# Altium schematic sheet JacdacRgbLedGeneric 60.SchDoc
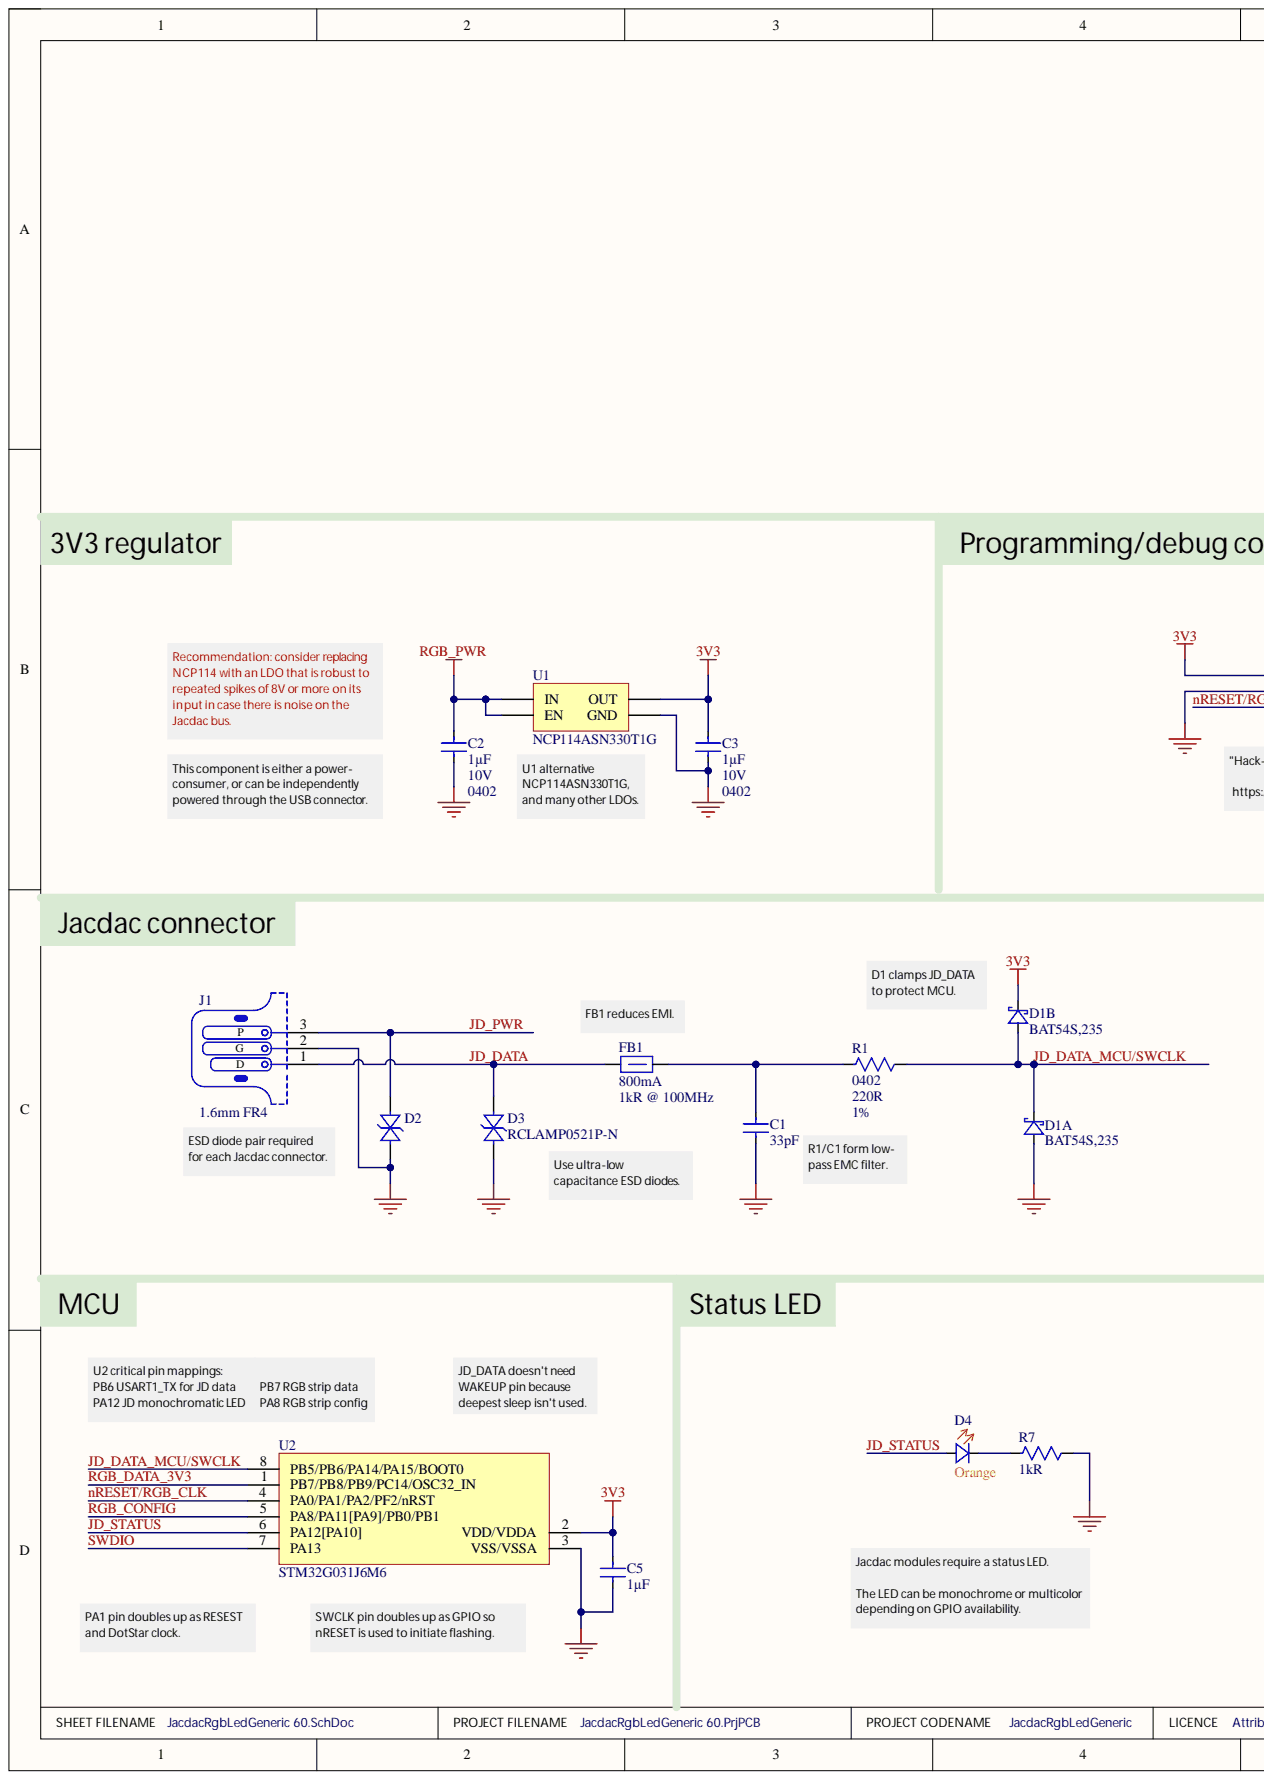
[diagram p0: JacdacRgbLedGeneric 60.SchDoc, left]
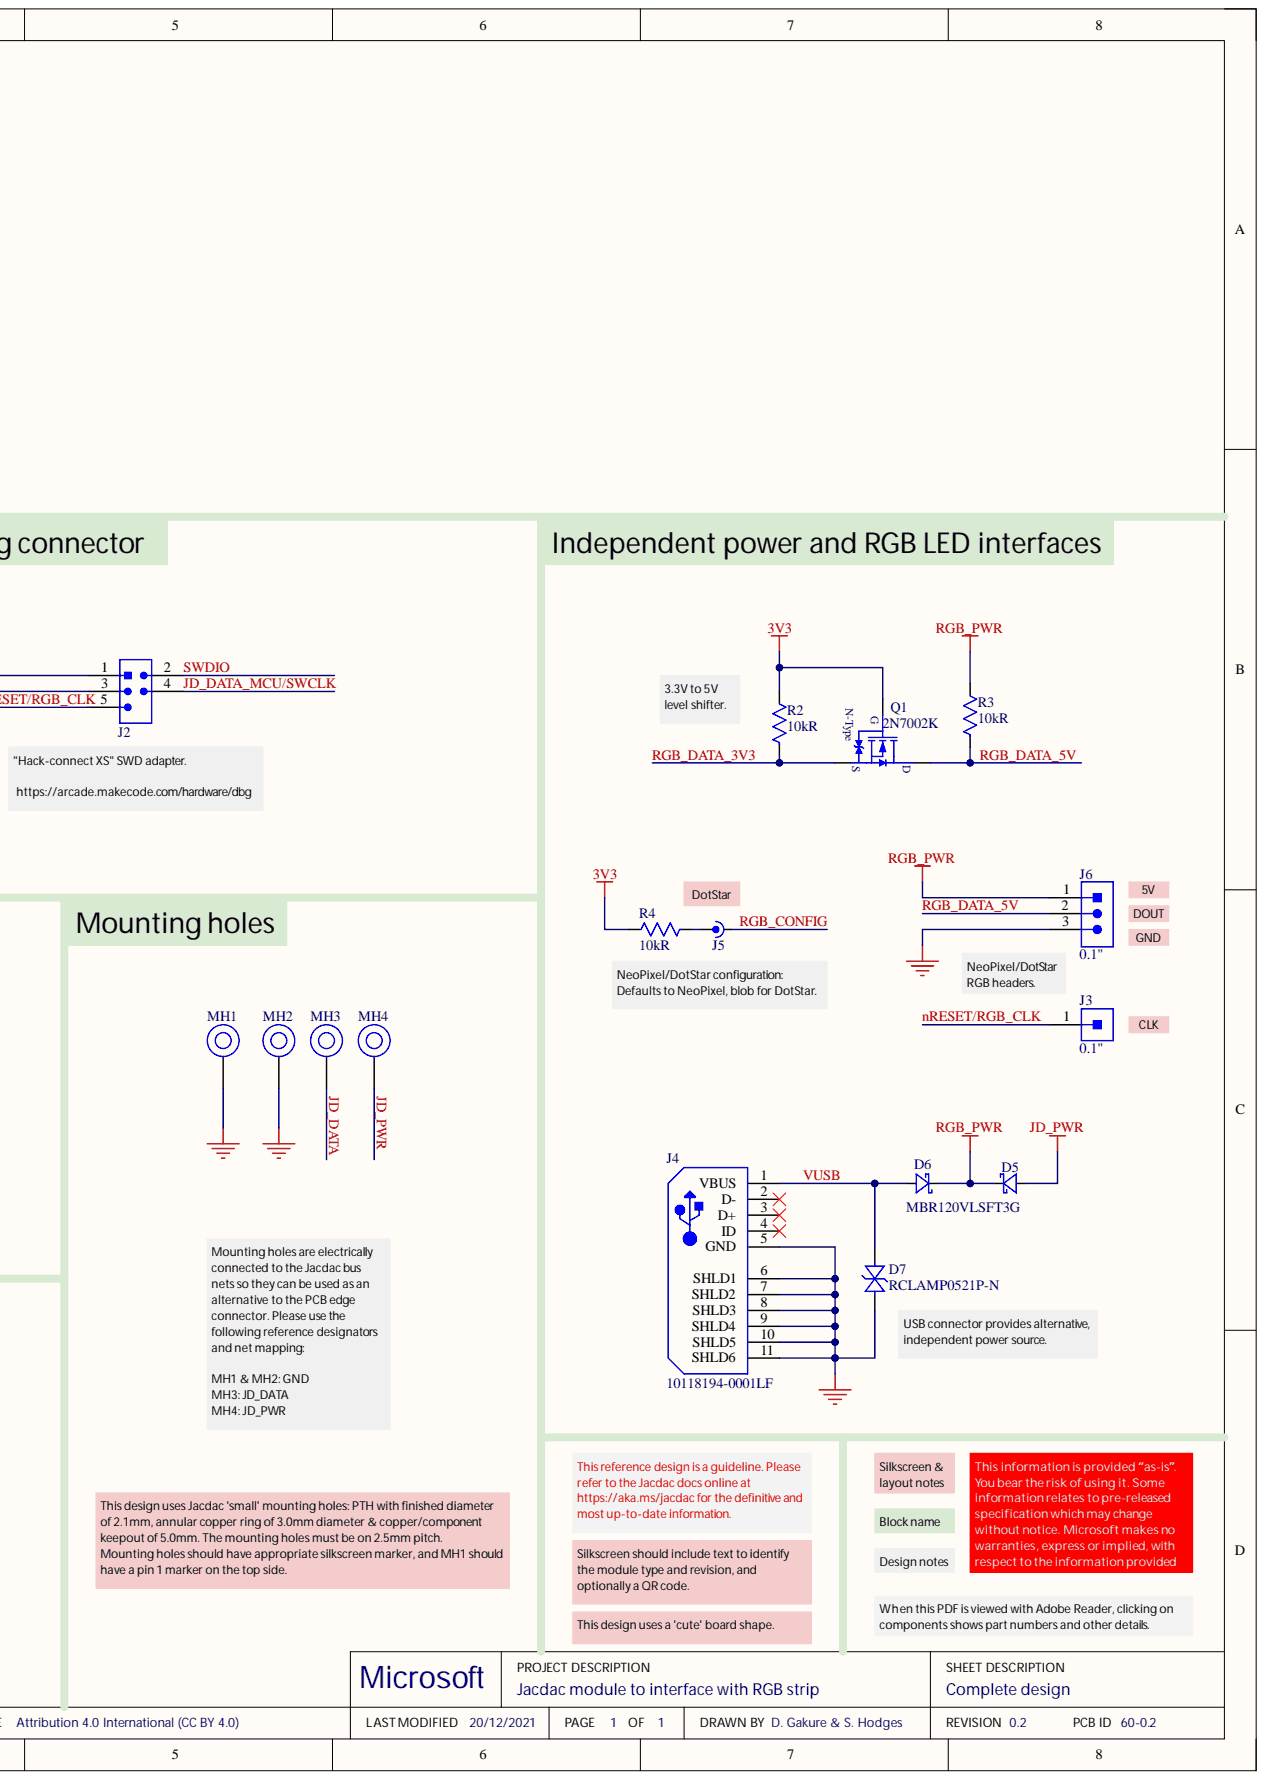
[diagram p1: JacdacRgbLedGeneric 60.SchDoc, right]

## Parts
C1  Capacitance: 33pF
C2  Capacitance: 1µF  Case Code (Imperial): 0402  Voltage Rating: 10V
C3  Capacitance: 1µF  Case Code (Imperial): 0402  Voltage Rating: 10V
C5  Capacitance: 1µF
D1  Manufacturer Part Number: BAT54S,235  (parts A,B)
D2  (no value)
D3  Manufacturer Part Number: RCLAMP0521P-N
D4  Colour: Orange
D5  Manufacturer Part Number: MBR120VLSFT3G
D6  Manufacturer Part Number: MBR120VLSFT3G
D7  Manufacturer Part Number: RCLAMP0521P-N
FB1  Current Rating: 800mA  Impedance: 1kR @ 100MHz
J1  PCB Type: 1.6mm FR4
J2  (no value)
J3  (no value)
J4  Manufacturer Part Number: 10118194-0001LF
J5  (no value)
J6  (no value)
MH1  (no value)
MH2  (no value)
MH3  (no value)
MH4  (no value)
Q1  Manufacturer Part Number: 2N7002K
R1  Case Code (Imperial): 0402  Resistance: 220R  Tolerance: 1%
R2  Resistance: 10kR
R3  Resistance: 10kR
R4  Resistance: 10kR
R7  Resistance: 1kR
U1  Manufacturer Part Number: NCP114ASN330T1G
U2  Manufacturer Part Number: STM32G031J6M6

## Nets
3V3: C3.2 C5.2 D1.2 J2.1 Q1.1 R2.1 R4.1 U1.5(OUT) U2.2(VDD/VDDA)
GND: C1.1 C2.1 C3.1 C5.1 D1.1 D2.2 D3.2 D7.2 J1.2 J2.3 J4.5(GND) J4.6(SHLD1) J4.7(SHLD2) J4.8(SHLD3) J4.9(SHLD4) J4.10(SHLD5) J4.11(SHLD6) J6.3 MH1.1 MH2.1 R7.1 U1.2(GND) U2.3(VSS/VSSA)
RGB_PWR: C2.2 D5.1 D6.1 J6.1 R3.1 U1.1(IN) U1.3(EN)
JD_DATA: D3.1 FB1.1 J1.1 MH3.1
JD_DATA_MCU/SWCLK: D1.3 J2.4 R1.1 U2.8(PB5/PB6/PA14/PA15/BOOT0)
JD_PWR: D2.1 D5.2 J1.3 MH4.1
JD_STATUS: D4.2 U2.6(PA12[PA10])
nRESET/RGB_CLK: J2.5 J3.1 U2.4(PA0/PA1/PA2/PF2/nRST)
RGB_CONFIG: J5.2 U2.5(PA8/PA11[PA9]/PB0/PB1)
RGB_DATA_3V3: Q1.2 R2.2 U2.1(PB7/PB8/PB9/PC14/OSC32_IN)
RGB_DATA_5V: J6.2 Q1.3 R3.2
SWDIO: J2.2 U2.7(PA13)
VUSB: D6.2 D7.1 J4.1(VBUS)
NetC1_2: C1.2 FB1.2 R1.2
NetD4_1: D4.1 R7.2
NetJ5_1: J5.1 R4.2

Not connected: J4 2(D-) 3(D+) 4(ID)

## Library data of the parts
C1 = (no symbol name): CAP CER 33PF 50V NP0 0402; Manufacturer: Samsung; Manufacturer Part Number: CL05C330JB51PNC; Tolerance: 5%; Case Code (Imperial): 0402; Case Code (Metric): 1005; Case/Package: 0402; Category: Capacitors; ComponentLink1Description: Samsung datasheet online; ComponentLink1URL: http://www.samsungsem.com/kr/support/library/product-catalog/mlcc.jsp; Max Operating Temperature: 125°C; Min Operating Temperature: -55°C; Ratings: AEC-Q200; Supplier 1: Digi-Key; Supplier 2: Mouser; Supplier 3: Future Electronics; Supplier Part Number 1: 1276-6515-1-ND; Supplier Part Number 2: 187-CL05C330JB51PNC; Supplier Part Number 3: 6022520; Voltage Rating: 50V
C2 C3 C5 = (no symbol name): CAP CER 1UF 10V X5R 0402; Manufacturer: Samsung; Manufacturer Part Number: CL05A105KP5NNNC; Material: Ceramic; Tolerance: 10%; Case Code (Metric): 1005; Case/Package: 0402; Category: Capacitors; ComponentLink1Description: Online datasheet; ComponentLink1URL: https://datasheet.ciiva.com/15195/getdatasheetpartid-358390-15195630.pdf; Contact Plating: Tin; Dielectric: X5R; Max Operating Temperature: 85°C; Min Operating Temperature: -55°C; Supplier 1: Digi-Key; Supplier 2: Mouser; Supplier 3: Farnell; Supplier Part Number 1: 1276-1076-1-ND; Supplier Part Number 2: 187-CL05A105KP5NNNC; Supplier Part Number 3: 3013329
  C5: Case Code (Imperial): 0402; Voltage Rating: 10V
D1 = (no symbol name): DIODE ARRAY SCHOTTKY 30V SOT23; Manufacturer: Nexperia; Category: Rectifier Diodes; ComponentLink1Description: NXP datasheet online; ComponentLink1URL: https://assets.nexperia.com/documents/data-sheet/BAT54_SER.pdf; Supplier 1: Digi-Key; Supplier 2: Mouser; Supplier 3: RSComponents; Supplier Part Number 1: 1727-1868-1-ND; Supplier Part Number 2: 771-BAT54S235; Supplier Part Number 3: 1707990
D2 = (no symbol name): Bidirectional 5V (Max) 6V 16V 3.5A (8/20us) 0402 TVS RoHS; Manufacturer: Born Semiconductor; Manufacturer Part Number: RCLAMP0521P-N; ComponentLink1Description: Online datasheet; ComponentLink1URL: https://datasheet.lcsc.com/szlcsc/Bourne-Semicon-Shenzhen-RCLAMP0521P-N_C316043.pdf; Package: 0402; Supplier1: LCSC; Supplier1 Part Number: C316043
D3 D7 = (no symbol name): Bidirectional 5V (Max) 6V 16V 3.5A (8/20us) 0402 TVS RoHS; Manufacturer: Born Semiconductor; ComponentLink1Description: Online datasheet; ComponentLink1URL: https://datasheet.lcsc.com/szlcsc/Bourne-Semicon-Shenzhen-RCLAMP0521P-N_C316043.pdf; Package: 0402; Supplier1: LCSC; Supplier1 Part Number: C316043
D4 = (no symbol name): 1.6 x 0.8 x 0.55mm (0603) LED 605nm Orange; Manufacturer: Rohm Semiconductor; Manufacturer Part Number: SML-D12D8WT86; Current - Test: 20mA; Lens Style/Size: Rectangle with Flat Top, 1.2mm x 0.8mm; Lens Type: Diffused, White; Millicandela Rating: 100mcd; Voltage - Forward (Vf) (Typ): 2.2V; Category: Optoelectronics; ComponentLink1Description: Online datasheet; ComponentLink1URL: https://d1d2qsbl8m0m72.cloudfront.net/en/products/databook/datasheet/opto/led/chip_mono/sml-d12x8_d13x8-e.pdf; Luminous Flux @ Current - Test: -; Package / Case: 0603 (1608 Metric); Size / Dimension: 1.60mm L x 0.80mm W; Supplier 1: Digi-Key; Supplier Part Number 1: 511-1577-1-ND; Viewing Angle: -; Wavelength - Dominant: 605nm; Wavelength - Peak: -
D5 D6 = (no symbol name): DIODE SCHOTTKY 20V 1A SOD123FL; Manufacturer: ON Semiconductor; Element Configuration: Single; Forward Voltage: 340mV; Max Forward Surge Current (Ifsm): 45A; Max Reverse Leakage Current: 600uA; Polarity: Standard; Average Rectified Current: 1A; Category: Schottky Diodes; ComponentLink4Description: On Semi datasheet online; ComponentLink4URL: https://datasheet.ciiva.com/6380/mbr120vlsft1-d-6380910.pdf; Current Rating: 1A; Forward Current: 1A; Halogen Free: Halogen Free; Max Operating Temperature: 125°C; Max Repetitive Reverse Voltage (Vrrm): 20V; Max Reverse Voltage (DC): 20V; Max Surge Current: 45A; Min Operating Temperature: -65°C; Number of Pins: 2; Output Current: 1A; Peak Non-Repetitive Surge Current: 45A; Peak Reverse Current: 600uA; Supplier 1: Digi-Key; Supplier 2: Mouser; Supplier 3: RSComponents; Supplier Part Number 1: MBR120VLSFT3GOSCT-ND; Supplier Part Number 2: 863-MBR120VLSFT3G; Supplier Part Number 3: 1867479; Voltage Rating (DC): 20V
FB1 = (no symbol name): 0603 1k@100MHz 0.8A chip; Manufacturer: TDK; Manufacturer Part Number: MPZ1608S102ATA00; Core Material: Ferrite; DC Resistance (DCR): 300mR; Tolerance: 25%; Case Code (Imperial): 0603; Case Code (Metric): 1608; Case/Package: 0603; ComponentLink2Description: datasheet online; ComponentLink2URL: https://www.mouser.co.uk/datasheet/2/400/eads_commercial_power_mpz1608_en-923650.pdf; ComponentLink3Description: datasheet; Max DC Current: 800mA; Supplier 1: Digi-Key; Supplier 2: Mouser; Supplier Part Number 1: 445-8694-1-ND; Supplier Part Number 2: 810-MPZ1608S102ATA00; ComponentLink3URL: Y:\System\Altium\Libraries 2014\TDK\Datasheets\eads_commercial_power_mpz1608_en-923650.pdf
J1 = (no symbol name): 3 pin JACDAC edge connector 1.27mm pitch; Manufacturer Part Number: JACDAC_EDGE_CONNECTOR; ComponentLink1Description: Yuliang JACDAC cable connector drawing online; ComponentLink1URL: https://github.com/microsoft/jacdac-mdk/blob/main/connector/JACDAC-Connector-by-Yuliang-2020-11-28.pdf; ComponentLink2Description: JACDAC PCB edge connector spec onlin; ComponentLink2URL: https://github.com/microsoft/jacdac-mdk/blob/main/connector/JACDAC_PCB_Edge_Connector_Drawing_JD-PEC-01_rev_01.pdf
J2 = (no symbol name): Hack Connect Mini 1.27 mm pitch 2-row
J3 = (no symbol name): 2.54mm, 1x1 way; Contact Termination: Solder; No. of Contacts: 4; No. of Rows: 1; Pitch Spacing: 2.54; ComponentLink1Description: header strip datasheet; ComponentLink1URL: http://www.farnell.com/datasheets/1518407.pdf; Lead Spacing: 2.54
J4 = (no symbol name): CONN USB MICRO B RECPT SMT R/A; Manufacturer: Amphenol FCI; Connector Type: USB - micro B; Current Rating: 1.8A per Contact; Gender: Receptacle; Insulation Resistance: 1GR; Material Flammability Rating: UL94 V-0; Mating Cycles: 10000; Max Voltage Rating (AC): 100V; Number of Contacts: 5; Number of Ports: 1; Pitch: 0.65mm; Shielding: Shielded; Specifications: USB 2.0; Voltage - Rated: 100VAC; Category: Connectors, Interconnects; Color: Black; ComponentLink1Description: FCI drawing; ComponentLink1URL: http://portal.fciconnect.com/Comergent//fci/drawing/10118194.pdf; ComponentLink2Description: FCI datasheet; ComponentLink2URL: https://cdn.amphenol-icc.com/media/wysiwyg/files/documentation/datasheet/inputoutput/io_usb_micro.pdf; Contact Finish: Gold; Contact Material: Copper Alloy; Contact Plating: Gold; Features: Solder Retention; Flammability Rating: UL94 V-0; Housing Material: Polymer; Ingress Protection: -; Insulation Color: Black; Max Operating Temperature: 85°C; Min Operating Temperature: -55°C; Mounting Feature: Horizontal; Number of Terminals/Pins: 5; Operating Temperature: -30°C ~ 80°C; Orientation: Right Angle; Part Status: Active; Shell/Shielding Material: Steel, Stainless; Supplier 1: Mouser; Supplier 2: Arrow Electronics; Supplier 3: Future Electronics; Supplier Part Number 1: 649-10118194-0001LF; Supplier Part Number 2: 10118194-0001LF; Supplier Part Number 3: 9100242; Termination: Solder
J5 = (no symbol name): Open solder blob
J6 = (no symbol name): 2.54mm, 3x1 way; Contact Termination: Solder; No. of Contacts: 4; No. of Rows: 1; Pitch Spacing: 2.54; ComponentLink1Description: header strip datasheet; ComponentLink1URL: http://www.farnell.com/datasheets/1518407.pdf; Lead Spacing: 2.54
MH1-MH4 = (no symbol name): Mounting Hole 5.0mm keepout, 3.0mm annualar ring and 2.1mm Drill PTH
Q1 = (no symbol name): MOSFET Transistor, N Channel, 300 mA, 60 V, 2 ohm, 10 V, 2.5 V; Manufacturer: ON Semiconductor / Fairchild; Continuous Drain Current (ID): 300mA; Drain to Source Breakdown Voltage: 60V; Drain to Source Resistance: 2R; Element Configuration: Single; Gate to Source Voltage (Vgs): 20V; Input Capacitance: 50pF; Max Power Dissipation: 350mW; Number of Elements: 1; Polarity: N-CHANNEL; Threshold Voltage: 2.5V; Turn-Off Delay Time: 30ns; Turn-On Delay Time: 5ns; Case/Package: SOT-23; Category: MOSFETs; ComponentLink1Description: Online datasheet; ComponentLink1URL: https://www.onsemi.com/pdf/datasheet/2n7002k-d.pdf; Contact Plating: Tin,Matte; Drain to Source Voltage (Vdss): 60V; Drain-source On Resistance-Max: 2R; Max Operating Temperature: 150°C; Min Operating Temperature: -55°C; Number of Pins: 3; On-State Resistance: 2R; Power Dissipation: 350mW; Rds On Max: 2R; Supplier 1: Digi-Key; Supplier 2: Mouser; Supplier 3: Farnell; Supplier Part Number 1: 2N7002KCT-ND; Supplier Part Number 2: 512-2N7002K; Supplier Part Number 3: 2453382; Voltage: 60V
R1 = (no symbol name): RES SMD 220 OHM 1% 1/16W 0402; Manufacturer: Yageo; Manufacturer Part Number: RC0402FR-07220RL; Max Power Dissipation: 63mW; Power Rating: 62.5mW; Temperature Coefficient: 100ppm/°C; Voltage Rating: 50V; Case Code (Metric): 1005; Case/Package: 0402; Category: Resistors; ComponentLink1Description: Online datasheet; ComponentLink1URL: https://datasheet.ciiva.com/11819/0900766b80c66297-11819466.pdf; Features: Moisture Resistant; Max Operating Temperature: 155°C; Min Operating Temperature: -55°C; Number of Pins: 2; Number of Terminations: 2; Resistor Type: Thick Film; Supplier 1: Digi-Key; Supplier 2: Mouser; Supplier 3: Farnell; Supplier Part Number 1: 311-220LRCT-ND; Supplier Part Number 2: 603-RC0402FR-07220RL; Supplier Part Number 3: 2147864; Termination: SMD/SMT; Voltage Rating (DC): 50V
R2-R4 = (no symbol name): RES SMD 10K OHM 1% 1/16W 0402; Manufacturer: Yageo; Manufacturer Part Number: RC0402FR-0710KL; Power Rating: 62.5mW; Temperature Coefficient: 100ppm/°C; Voltage Rating: 50V; Case Code (Imperial): 0402; Case Code (Metric): 1005; Case/Package: 0402; Category: Resistors; ComponentLink1Description: https://datasheet.ciiva.com/7660/38568-7660787.pdf?src-supplier=Element14; ComponentLink1URL: https://datasheet.ciiva.com/7660/38568-7660787.pdf?src-supplier=Element14; ComponentLink2Description: https://datasheet.ciiva.com/7849/1784430-7849813.pdf?src-supplier=Element14; ComponentLink2URL: https://datasheet.ciiva.com/7849/1784430-7849813.pdf?src-supplier=Element14; ComponentLink3Description: https://datasheet.ciiva.com/7849/1686128-7849814.pdf?src-supplier=Element14; ComponentLink3URL: https://datasheet.ciiva.com/7849/1686128-7849814.pdf?src-supplier=Element14; ComponentLink4Description: https://datasheet.ciiva.com/7849/1784430-7849815.pdf?src-supplier=Element14; ComponentLink4URL: https://datasheet.ciiva.com/7849/1784430-7849815.pdf?src-supplier=Element14; ComponentLink5Description: https://datasheet.ciiva.com/22747/1018594-22747512.pdf?src-supplier=Element14; ComponentLink5URL: https://datasheet.ciiva.com/22747/1018594-22747512.pdf?src-supplier=Element14; ComponentLink6Description: https://datasheet.ciiva.com/12667/pyu-rc0402-51-rohs-l-6-r-12667067.pdf?src-supplier=Mouser; ComponentLink6URL: https://datasheet.ciiva.com/12667/pyu-rc0402-51-rohs-l-6-r-12667067.pdf?src-supplier=Mouser; ComponentLink7Description: https://datasheet.ciiva.com/7983/1018594-7983486.pdf?src-supplier=Element14; ComponentLink7URL: https://datasheet.ciiva.com/7983/1018594-7983486.pdf?src-supplier=Element14; Lead Pitch: 0.2mm; Max Operating Temperature: 155°C; Min Operating Temperature: -55°C; Number of Pins: 2; Number of Terminations: 2; Resistor Type: Thick Film; Supplier 1: Digi-Key; Supplier 2: Mouser; Supplier 3: Farnell; Supplier Part Number 1: 311-10.0KLRCT-ND; Supplier Part Number 2: 603-RC0402FR-0710KL; Supplier Part Number 3: 2363463; Termination: SMD/SMT; Tolerance: 1%; Voltage Rating (DC): 50V; Working Voltage: 50V
R7 = (no symbol name): RES SMD 1K OHM 1% 1/16W 0402; Manufacturer: Yageo; Manufacturer Part Number: RC0402FR-071KL; Power Rating: 62.5mW; Temperature Coefficient: 100ppm/°C; Voltage Rating: 50V; Case Code (Imperial): 0402; Case Code (Metric): 1005; Case/Package: 0402; Category: Resistors; ComponentLink2Description: Online datasheet; ComponentLink2URL: https://datasheet.ciiva.com/12666/pyu-rc0402-51-rohs-l-6-r-12666741.pdf; Max Operating Temperature: 155°C; Min Operating Temperature: -55°C; Number of Pins: 2; Resistor Type: Thick Film; Supplier 1: Digi-Key; Supplier 2: Mouser; Supplier 3: Farnell; Supplier Part Number 1: 311-1.00KLRDKR-ND; Supplier Part Number 2: 603-RC0402FR-071KL; Supplier Part Number 3: 2132441; Termination: SMD/SMT; Tolerance: 1%; Voltage Rating (DC): 50V
U1 = (no symbol name): ON SEMICONDUCTOR - NCP114ASN330T1G - LDO Voltage Regulator, Fixed, 1.7 V to 5.5 V in, 3.3 V/300 mA out, 150 mV drop, TSOP-5; Dropout Voltage: 225mV; Max Input Voltage: 5.5V; Min Output Voltage: 3.3V; Number of Regulators: 1; Output Configuration: Positive; Output Current: 300mA; Power Supply Rejection Ratio (PSRR): 75dB; Quiescent Current: 95uA; Case/Package: SOT-23-5; Category: Voltage Regulators - Linear; ComponentLink3Description: On Semi datasheet online; ComponentLink3URL: http://www.onsemi.com/pub/Collateral/NCP114-D.PDF; Manufacturer: ON Semiconductor; Max Operating Temperature: 85°C; Min Operating Temperature: -40°C; Output Type: Fixed; Supplier 1: Digi-Key; Supplier 2: RSComponents; Supplier 3: Mouser; Supplier Part Number 1: NCP114ASN330T1GOSCT-ND; Supplier Part Number 2: 1263178; Supplier Part Number 3: 863-NCP114ASN330T1G
U2 = (no symbol name): MCU 32-bit ARM Cortex M0+ RISC 32KB Flash 2.5V/3.3V 8-Pin SO N Tube; Manufacturer: STMicroelectronics; Category: Microcontrollers; ComponentLink1Description: Online datasheet; ComponentLink1URL: https://www.st.com/resource/en/datasheet/stm32g031c6.pdf; Supplier 1: Digi-Key; Supplier 2: Future Electronics; Supplier 3: Mouser; Supplier Part Number 1: 497-19552-ND; Supplier Part Number 2: 2116576; Supplier Part Number 3: 511-STM32G031J6M6
